# Altium schematic sheet LEDs.SchDoc
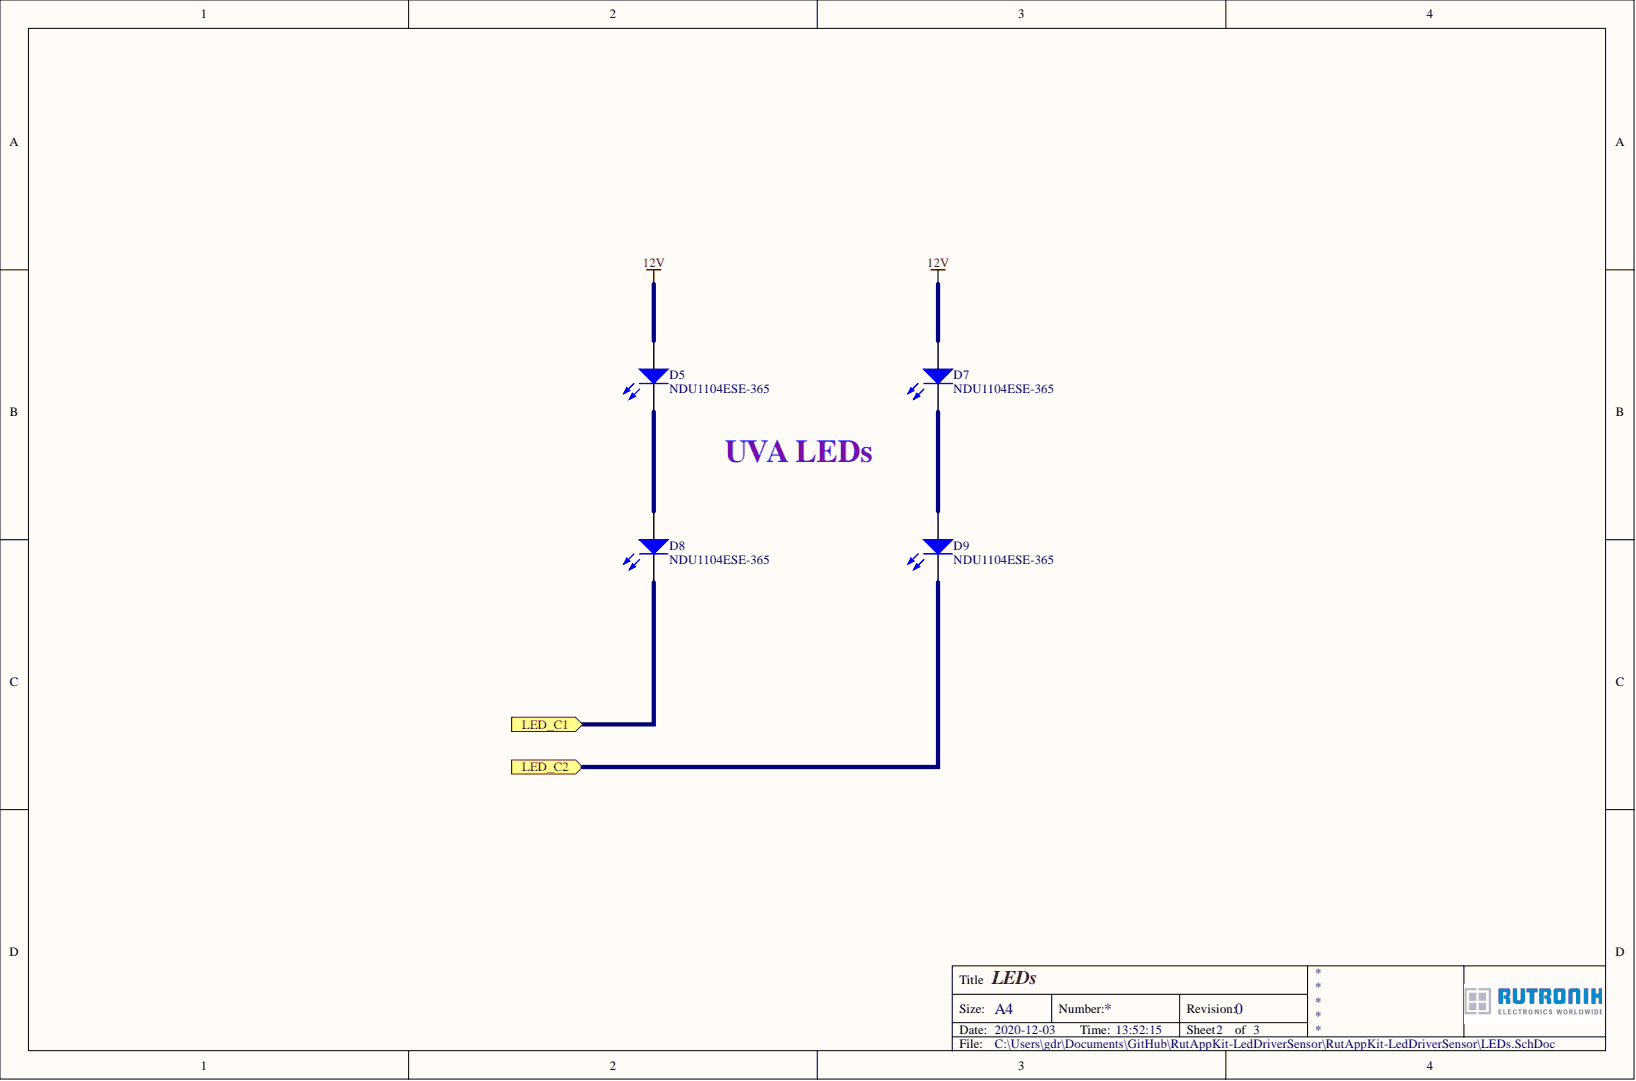
[diagram p0: LEDs.SchDoc]

## Parts
D5  NDU1104ESE-365  [NDU1104ESE]
D7  NDU1104ESE-365  [NDU1104ESE]
D8  NDU1104ESE-365  [NDU1104ESE]
D9  NDU1104ESE-365  [NDU1104ESE]

## Ports
LED_C1, LED_C2

## Nets
12V: D5.1 D7.1
LED_C1: D8.2 ; port LED_C1
LED_C2: D9.2 ; port LED_C2
NetD5_2: D5.2 D8.1
NetD7_2: D7.2 D9.1

## Library data of the parts
D5 D7-D9 = LED2: Typical SMD LED; Manufacturer: Stanley Electric; Manufacturer Part No.: NDU1104ESE-405-TR; Part Description: High Power UV-LED; Specification: UVA, 405nm, 500mA; Value: NDU1104ESE-405-TR; Component Kind: Standard; Library Name: Miscellaneous Devices.IntLib; Link: https://www.rutronik24.com/product/stanley/ndu1104ese-405-tr/12405034.html; Simulation: LED2; Supplier: Rutronik; Supplier part number: IR7213
